# Altium schematic sheet 4-Buttons & Encoder.SchDoc("4-Buttons & Encoder")
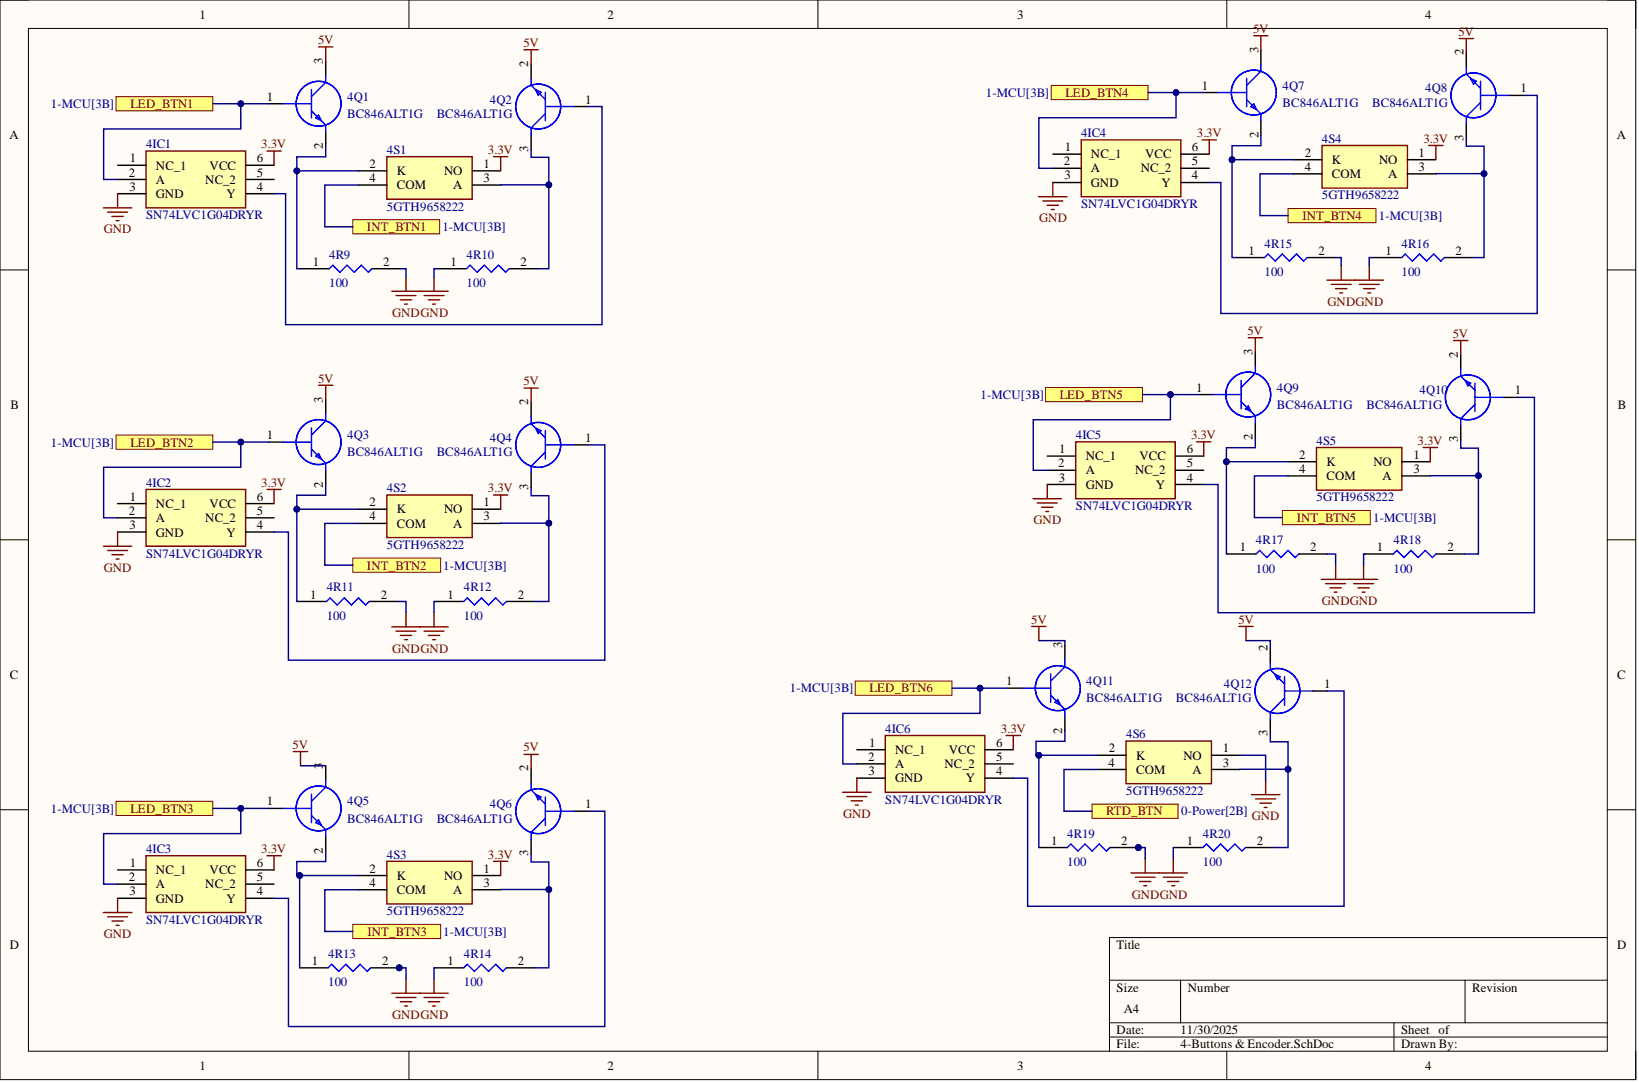
[diagram p0: 4-Buttons & Encoder.SchDoc]

## Parts
4IC1  SN74LVC1G04DRYR
4IC2  SN74LVC1G04DRYR
4IC3  SN74LVC1G04DRYR
4IC4  SN74LVC1G04DRYR
4IC5  SN74LVC1G04DRYR
4IC6  SN74LVC1G04DRYR
4Q1  BC846ALT1G
4Q2  BC846ALT1G
4Q3  BC846ALT1G
4Q4  BC846ALT1G
4Q5  BC846ALT1G
4Q6  BC846ALT1G
4Q7  BC846ALT1G
4Q8  BC846ALT1G
4Q9  BC846ALT1G
4Q10  BC846ALT1G
4Q11  BC846ALT1G
4Q12  BC846ALT1G
4R9  100
4R10  100
4R11  100
4R12  100
4R13  100
4R14  100
4R15  100
4R16  100
4R17  100
4R18  100
4R19  100
4R20  100
4S1  5GTH9658222
4S2  5GTH9658222
4S3  5GTH9658222
4S4  5GTH9658222
4S5  5GTH9658222
4S6  5GTH9658222

## Ports
INT_BTN1, INT_BTN2, INT_BTN3, INT_BTN4, INT_BTN5, LED_BTN1, LED_BTN2, LED_BTN3, LED_BTN4, LED_BTN5, LED_BTN6, RTD_BTN

## Nets
3.3V: 4IC1.6(VCC) 4IC2.6(VCC) 4IC3.6(VCC) 4IC4.6(VCC) 4IC5.6(VCC) 4IC6.6(VCC) 4S1.1(NO) 4S2.1(NO) 4S3.1(NO) 4S4.1(NO) 4S5.1(NO)
5V: 4Q1.3 4Q2.2 4Q3.3 4Q4.2 4Q5.3 4Q6.2 4Q7.3 4Q8.2 4Q9.3 4Q10.2 4Q11.3 4Q12.2
GND: 4IC1.3(GND) 4IC2.3(GND) 4IC3.3(GND) 4IC4.3(GND) 4IC5.3(GND) 4IC6.3(GND) 4R9.2 4R10.1 4R11.2 4R12.1 4R13.2 4R14.1 4R15.2 4R16.1 4R17.2 4R18.1 4R19.2 4R20.1 4S6.1(NO)
INT_BTN1: 4S1.4(COM) ; port INT_BTN1
INT_BTN2: 4S2.4(COM) ; port INT_BTN2
INT_BTN3: 4S3.4(COM) ; port INT_BTN3
INT_BTN4: 4S4.4(COM) ; port INT_BTN4
INT_BTN5: 4S5.4(COM) ; port INT_BTN5
LED_BTN1: 4IC1.2(A) 4Q1.1 ; port LED_BTN1
LED_BTN2: 4IC2.2(A) 4Q3.1 ; port LED_BTN2
LED_BTN3: 4IC3.2(A) 4Q5.1 ; port LED_BTN3
LED_BTN4: 4IC4.2(A) 4Q7.1 ; port LED_BTN4
LED_BTN5: 4IC5.2(A) 4Q9.1 ; port LED_BTN5
LED_BTN6: 4IC6.2(A) 4Q11.1 ; port LED_BTN6
RTD_BTN: 4S6.4(COM) ; port RTD_BTN
Net4IC1_4: 4IC1.4(Y) 4Q2.1
Net4IC2_4: 4IC2.4(Y) 4Q4.1
Net4IC3_4: 4IC3.4(Y) 4Q6.1
Net4IC4_4: 4IC4.4(Y) 4Q8.1
Net4IC5_4: 4IC5.4(Y) 4Q10.1
Net4IC6_4: 4IC6.4(Y) 4Q12.1
Net4Q1_2: 4Q1.2 4R9.1 4S1.2(K)
Net4Q2_3: 4Q2.3 4R10.2 4S1.3(A)
Net4Q3_2: 4Q3.2 4R11.1 4S2.2(K)
Net4Q4_3: 4Q4.3 4R12.2 4S2.3(A)
Net4Q5_2: 4Q5.2 4R13.1 4S3.2(K)
Net4Q6_3: 4Q6.3 4R14.2 4S3.3(A)
Net4Q7_2: 4Q7.2 4R15.1 4S4.2(K)
Net4Q8_3: 4Q8.3 4R16.2 4S4.3(A)
Net4Q9_2: 4Q9.2 4R17.1 4S5.2(K)
Net4Q10_3: 4Q10.3 4R18.2 4S5.3(A)
Net4Q11_2: 4Q11.2 4R19.1 4S6.2(K)
Net4Q12_3: 4Q12.3 4R20.2 4S6.3(A)

Not connected: 4IC1 1(NC_1) 5(NC_2); 4IC2 1(NC_1) 5(NC_2); 4IC3 1(NC_1) 5(NC_2); 4IC4 1(NC_1) 5(NC_2); 4IC5 1(NC_1) 5(NC_2); 4IC6 1(NC_1) 5(NC_2)

## Library data of the parts
4IC1-4IC6 = SN74LVC1G04DRYR: Integrated Circuit; Manufacturer_Name: Texas Instruments; Arrow Part Number: SN74LVC1G04DRYR; Arrow Price/Stock: https://www.arrow.com/en/products/sn74lvc1g04dryr/texas-instruments?region=nac; Component Kind: Standard; Datasheet Link: http://www.ti.com/lit/gpn/sn74lvc1g04; Height: 0mm; Library Name: SamacSys.SchLib; Manufacturer_Part_Number: SN74LVC1G04DRYR; Mouser Part Number: 595-SN74LVC1G04DRYR; Mouser Price/Stock: https://www.mouser.co.uk/ProductDetail/Texas-Instruments/SN74LVC1G04DRYR?qs=7kv20MEVUlgyCI6MY8upNw%3D%3D
4Q1-4Q12 = BC846ALT1G: Transistor BJT NPN; Manufacturer_Name: onsemi; Arrow Part Number: BC846ALT1G; Arrow Price/Stock: https://www.arrow.com/en/products/bc846alt1g/on-semiconductor?utm_currency=USD&region=nac; Component Kind: Standard; Datasheet Link: https://www.onsemi.com/pub/Collateral/BC846ALT1-D.PDF; Height: 1.11mm; Library Name: SamacSys.SchLib; Manufacturer_Part_Number: BC846ALT1G; Mouser Part Number: 863-BC846ALT1G; Mouser Price/Stock: https://www.mouser.co.uk/ProductDetail/onsemi/BC846ALT1G?qs=vNc2DXHODiKaYXYnVvJi5w%3D%3D
4R9-4R20 = CRCW0805100RFKEC: Resistor; Manufacturer_Name: Vishay; Arrow Part Number: CRCW0805100RFKEC; Arrow Price/Stock: https://www.arrow.com/en/products/crcw0805100rfkec/vishay?region=nac; Component Kind: Standard; Datasheet Link: http://www.vishay.com/docs/20035/dcrcwe3.pdf; Height: 0.5mm; Library Name: SamacSys.SchLib; Manufacturer_Part_Number: CRCW0805100RFKEC; Mouser Part Number: 71-CRCW0805100RFKEC; Mouser Price/Stock: https://www.mouser.co.uk/ProductDetail/Vishay-Dale/CRCW0805100RFKEC?qs=waxnFHLl2hAP37ywEEHvOA%3D%3D
4S1-4S6 = 5GTH9658222: Switch; Manufacturer_Name: Apem; Component Kind: Standard; Datasheet Link: https://componentsearchengine.com/Datasheets/1/5GTH9658222.pdf; Height: 8.5mm; Library Name: SamacSys.SchLib; Manufacturer_Part_Number: 5GTH9658222; Mouser Part Number: 642-5GTH9658222; Mouser Price/Stock: https://www.mouser.co.uk/ProductDetail/Apem/5GTH9658222?qs=XkGcM6gst%2F9IbJCBFWFIXA%3D%3D
